# Altium schematic sheet Gpio_expander_gmsl.SchDoc
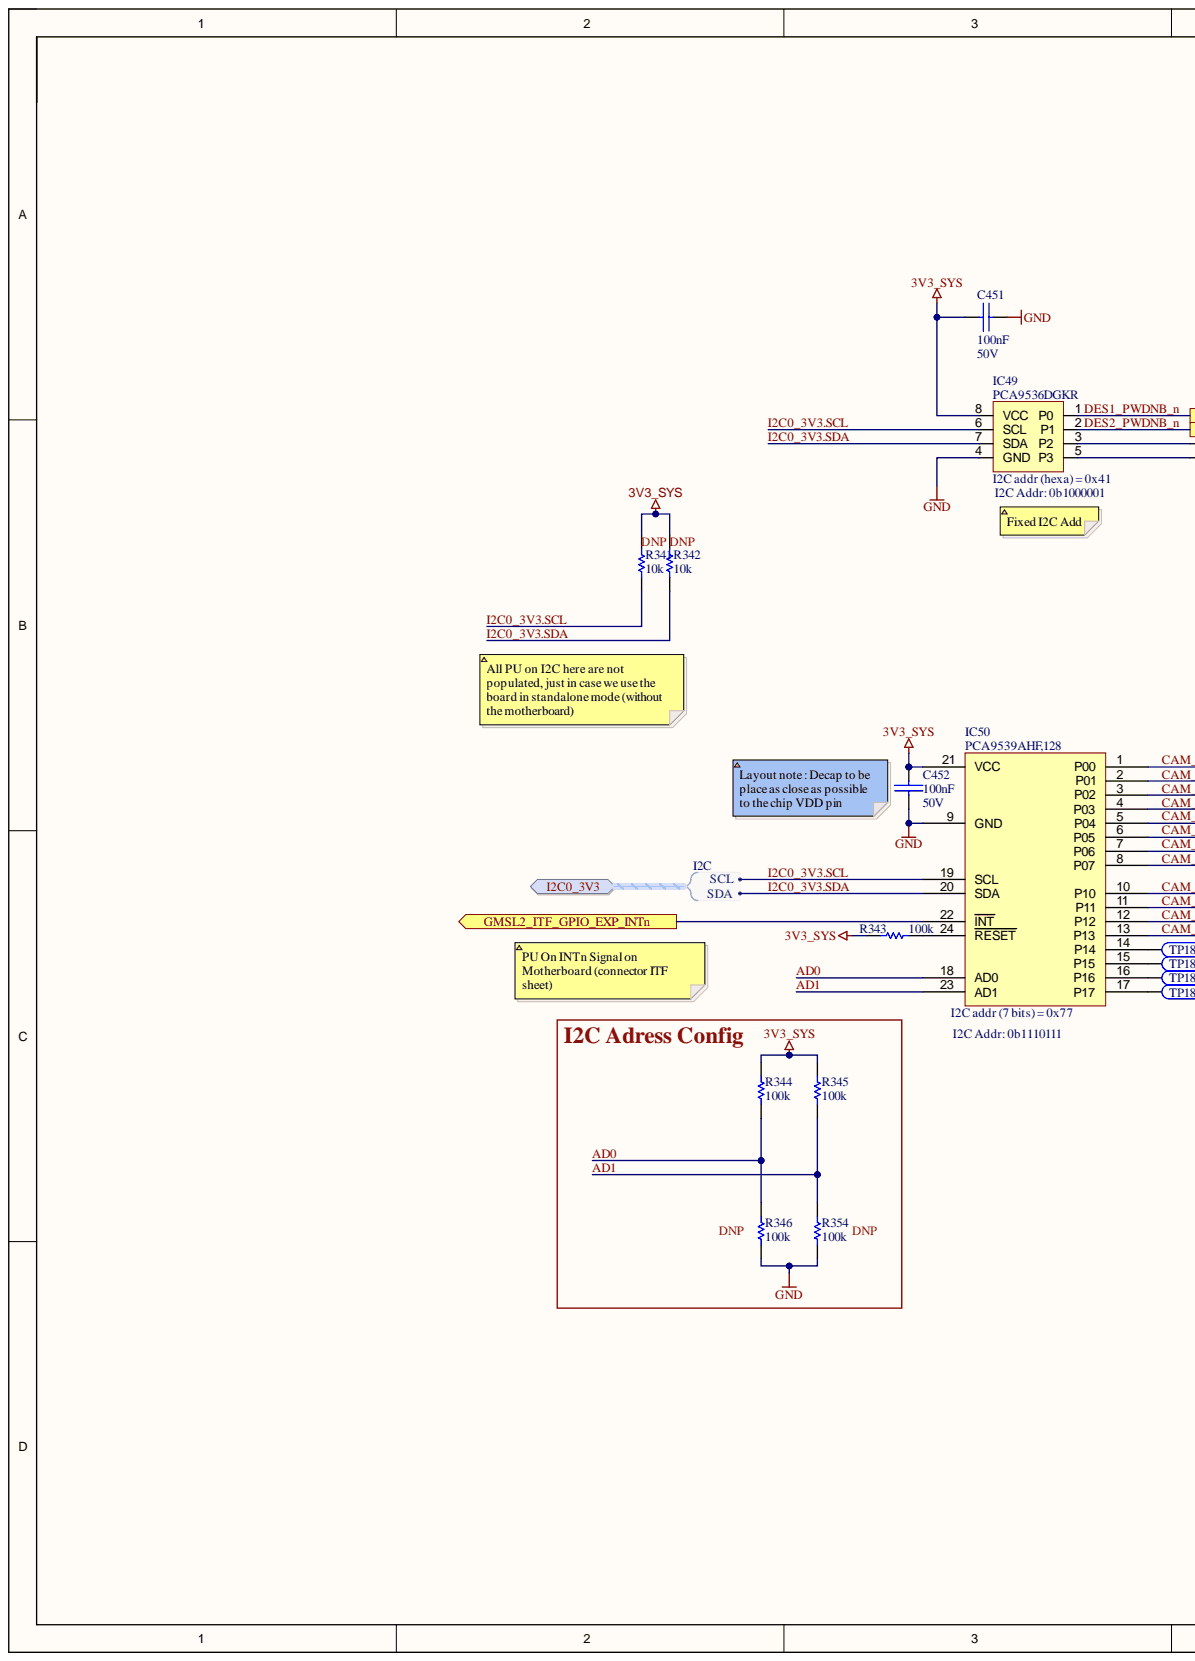
[diagram p0: Gpio_expander_gmsl.SchDoc, left]
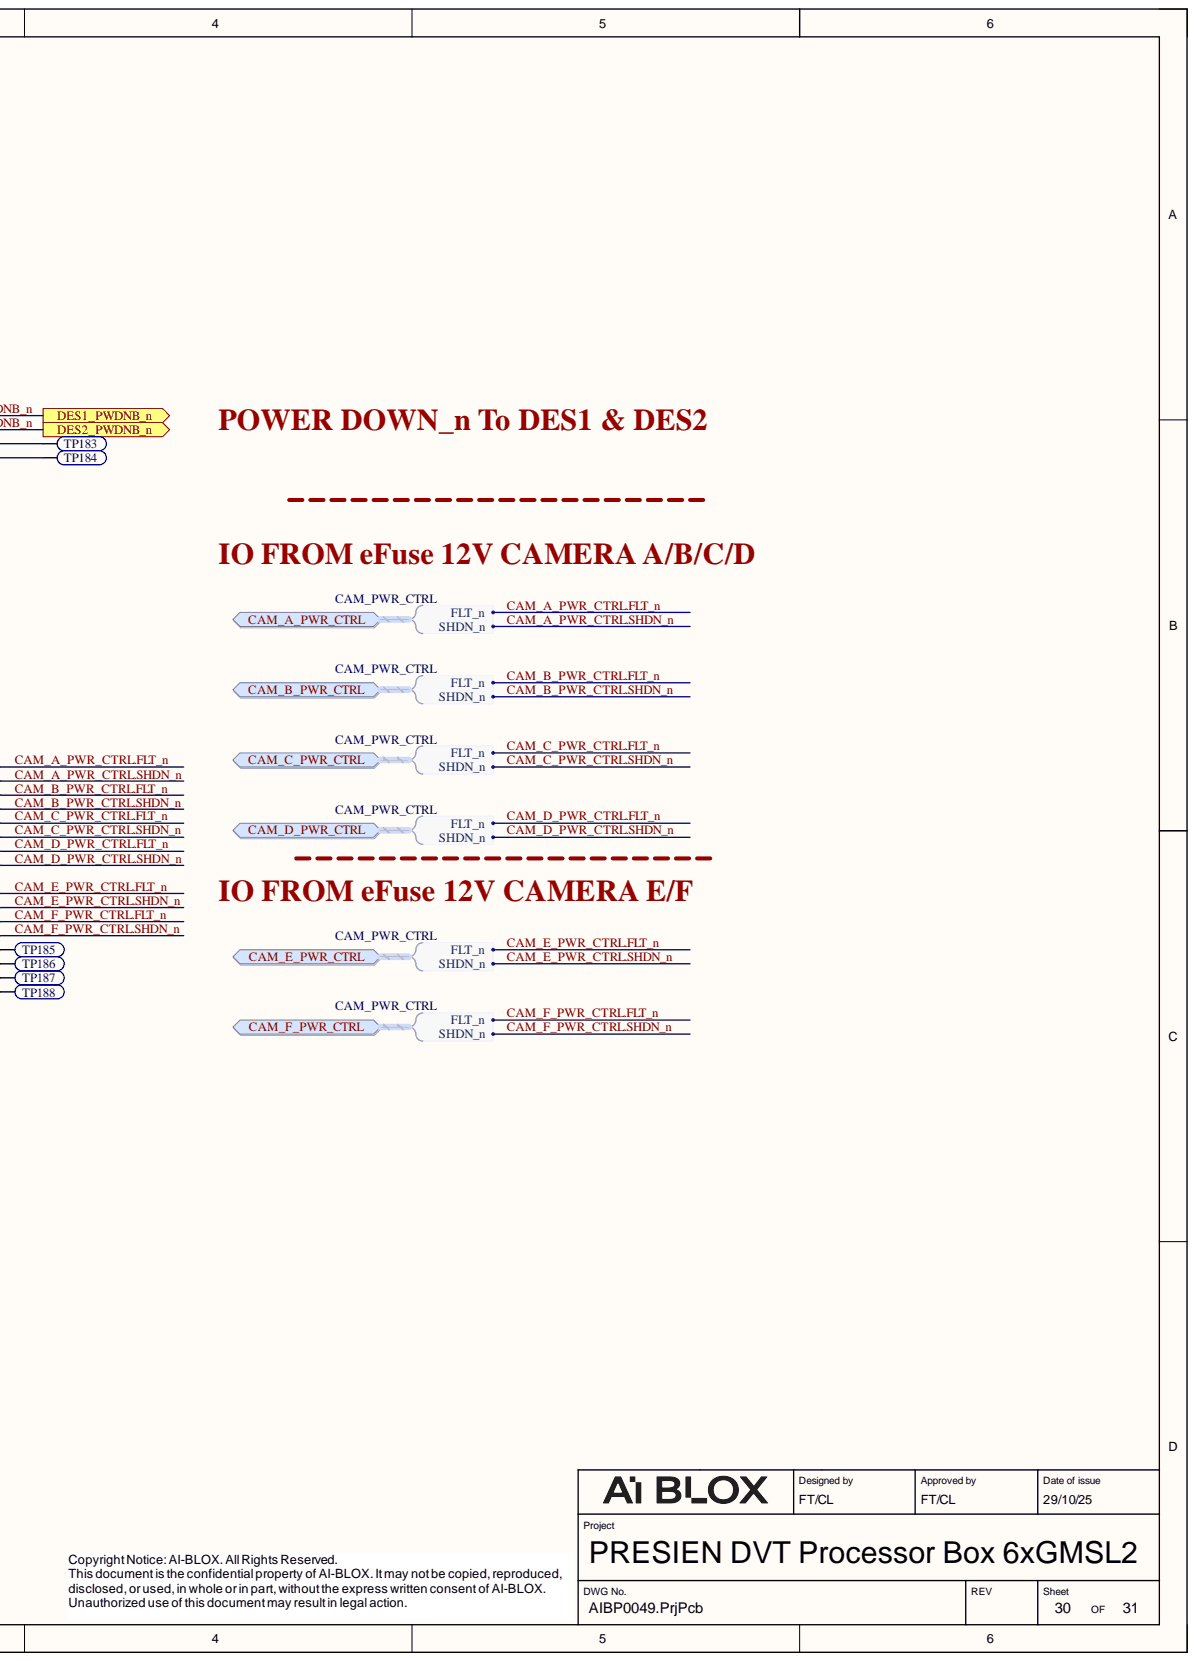
[diagram p1: Gpio_expander_gmsl.SchDoc, right]

## Parts
C451  value 100nF  Voltage: 50V  [CAP0603-M]  (hidden comment: CC0603KPX7R9BB104)
C452  value 100nF  Voltage: 50V  [CAP0603-M]  (hidden comment: CC0603KPX7R9BB104)
IC49  PCA9536DGKR  [DGK0008A_M]
IC50  PCA9539AHF,128  [NXP 24-HWQFN]
R341  value 10k  [RES0603]  (hidden comment: RC0603FR-0710KL)
R342  value 10k  [RES0603]  (hidden comment: RC0603FR-0710KL)
R343  value 100k  [RES0603]  (hidden comment: RC0603FR-07100KL)
R344  value 100k  [RES0603]  (hidden comment: RC0603FR-07100KL)
R345  value 100k  [RES0603]  (hidden comment: RC0603FR-07100KL)
R346  value 100k  [RES0603]  (hidden comment: RC0603FR-07100KL)
R354  value 100k  [RES0603]  (hidden comment: RC0603FR-07100KL)
TP183  Test_Point_1  [Test_Point_1]  (value not drawn)  (no BOM)
TP184  Test_Point_1  [Test_Point_1]  (value not drawn)  (no BOM)
TP185  Test_Point_1  [Test_Point_1]  (value not drawn)  (no BOM)
TP186  Test_Point_1  [Test_Point_1]  (value not drawn)  (no BOM)
TP187  Test_Point_1  [Test_Point_1]  (value not drawn)  (no BOM)
TP188  Test_Point_1  [Test_Point_1]  (value not drawn)  (no BOM)

## Ports
CAM_A_PWR_CTRL, CAM_A_PWR_CTRL.FLT_n, CAM_A_PWR_CTRL.SHDN_n, CAM_B_PWR_CTRL, CAM_B_PWR_CTRL.FLT_n, CAM_B_PWR_CTRL.SHDN_n, CAM_C_PWR_CTRL, CAM_C_PWR_CTRL.FLT_n, CAM_C_PWR_CTRL.SHDN_n, CAM_D_PWR_CTRL, CAM_D_PWR_CTRL.FLT_n, CAM_D_PWR_CTRL.SHDN_n, CAM_E_PWR_CTRL, CAM_E_PWR_CTRL.FLT_n, CAM_E_PWR_CTRL.SHDN_n, CAM_F_PWR_CTRL, CAM_F_PWR_CTRL.FLT_n, CAM_F_PWR_CTRL.SHDN_n, DES1_PWDNB_n, DES2_PWDNB_n, GMSL2_ITF_GPIO_EXP_INTn, I2C0_3V3, I2C0_3V3.SCL, I2C0_3V3.SDA

## Nets
3V3_SYS: C451.1 C452.1 IC49.8(VCC) IC50.21(VCC) R341.2 R342.2 R343.2 R344.1 R345.1
GND: C451.2 C452.2 IC49.4(GND) IC50.9(GND) R346.2 R354.2
AD0: IC50.18(AD0) R344.2 R346.1
AD1: IC50.23(AD1) R345.2 R354.1
CAM_A_PWR_CTRL.FLT_n: IC50.1(P00) ; port CAM_A_PWR_CTRL
CAM_A_PWR_CTRL.SHDN_n: IC50.2(P01) ; port CAM_A_PWR_CTRL
CAM_B_PWR_CTRL.FLT_n: IC50.3(P02) ; port CAM_B_PWR_CTRL
CAM_B_PWR_CTRL.SHDN_n: IC50.4(P03) ; port CAM_B_PWR_CTRL
CAM_C_PWR_CTRL.FLT_n: IC50.5(P04) ; port CAM_C_PWR_CTRL
CAM_C_PWR_CTRL.SHDN_n: IC50.6(P05) ; port CAM_C_PWR_CTRL
CAM_D_PWR_CTRL.FLT_n: IC50.7(P06) ; port CAM_D_PWR_CTRL
CAM_D_PWR_CTRL.SHDN_n: IC50.8(P07) ; port CAM_D_PWR_CTRL
CAM_E_PWR_CTRL.FLT_n: IC50.10(P10) ; port CAM_E_PWR_CTRL
CAM_E_PWR_CTRL.SHDN_n: IC50.11(P11) ; port CAM_E_PWR_CTRL
CAM_F_PWR_CTRL.FLT_n: IC50.12(P12) ; port CAM_F_PWR_CTRL
CAM_F_PWR_CTRL.SHDN_n: IC50.13(P13) ; port CAM_F_PWR_CTRL
DES1_PWDNB_n: IC49.1(P0) ; port DES1_PWDNB_n
DES2_PWDNB_n: IC49.2(P1) ; port DES2_PWDNB_n
GMSL2_ITF_GPIO_EXP_INTn: IC50.22(INT) ; port GMSL2_ITF_GPIO_EXP_INTn
I2C0_3V3.SCL: IC49.6(SCL) IC50.19(SCL) R341.1 ; port I2C0_3V3
I2C0_3V3.SDA: IC49.7(SDA) IC50.20(SDA) R342.1 ; port I2C0_3V3
NetIC49_3: IC49.3(P2) TP183.1
NetIC49_5: IC49.5(P3) TP184.1
NetIC50_14: IC50.14(P14) TP185.1
NetIC50_15: IC50.15(P15) TP186.1
NetIC50_16: IC50.16(P16) TP187.1
NetIC50_17: IC50.17(P17) TP188.1
NetIC50_24: IC50.24(RESET) R343.1

## Library data of the parts
C451 C452 = CMP-81.02.0001-14: CAP CER 0.1UF 50V X7R 0603; Manufacturer 1: YAGEO; GRADE: NO; Case/Package: 0603; Component Kind: Standard; DatasheetDocument: https://datasheet.octopart.com/CC0603KPX7R9BB104-Yageo-datasheet-151948686.pdf; Internal Ref: 81.02.0001; Manufacturer Part Number 1: CC0603KPX7R9BB104; ROHS: YES; Library Name: AI Blox; Publisher: Hans Stevens
IC49 = CMP-81.04.0144-2: IC I/O expander I2C expander 4-bits, 8-Pin VSSOP; Manufacturer 1: TI; GRADE: NO; Component Kind: Standard; DatasheetDocument: https://www.ti.com/general/docs/suppproductinfo.tsp?distId=10&gotoUrl=https%3A%2F%2Fwww.ti.com%2Flit%2Fgpn%2Fpca9536; Internal Ref: 81.04.0144; Package: VSSOP; ROHS: YES; Library Name: AI Blox; Publisher: Frederic Tarral
IC50 = CMP-81.04.0035-3: IC I/O EXPANDER I2C 24HWQFN; Manufacturer 1: NXP; GRADE: NO; Component Kind: Standard; DatasheetDocument: https://octopart.com/datasheet/pca9539ahf%2C128-nxp+semiconductors-24747490; Internal Ref: 81.04.0035; Manufacturer Part Number 1: PCA9539AHF,128; Package: 24-HWQFN; ROHS: YES; StockLoc: R02/B09/L03; Supplier 1: Digikey; Supplier Part Number 1: 568-9938-1-ND; Library Name: AI Blox; Publisher: Hans Stevens
R341 R342 = CMP-81.01.0021-5: RES 10K OHM 1% 1/10W 0603; GRADE: NO; Case/Package: 0603; Component Kind: Standard; DatasheetDocument: https://octopart.com/datasheet/rc0603fr-0710kl-yageo-40025538; Internal Ref: 81.01.0021; ROHS: YES; Library Name: AI Blox; Publisher: Hans Stevens
R343-R346 R354 = CMP-81.01.0001-5: RES 100K OHM 1% 1/10W 0603; GRADE: NO; Case/Package: 0603; Component Kind: Standard; DatasheetDocument: https://octopart.com/datasheet/rc0603fr-07100kl-yageo-40946036; Internal Ref: 81.01.0001; ROHS: YES; Library Name: AI Blox; Publisher: Hans Stevens
TP183-TP188 = CMP-81.00.0006-9: 0.8mm testpoint; Library Name: AI Blox
